# Altium schematic sheet ElectricalDiagram.SchDoc
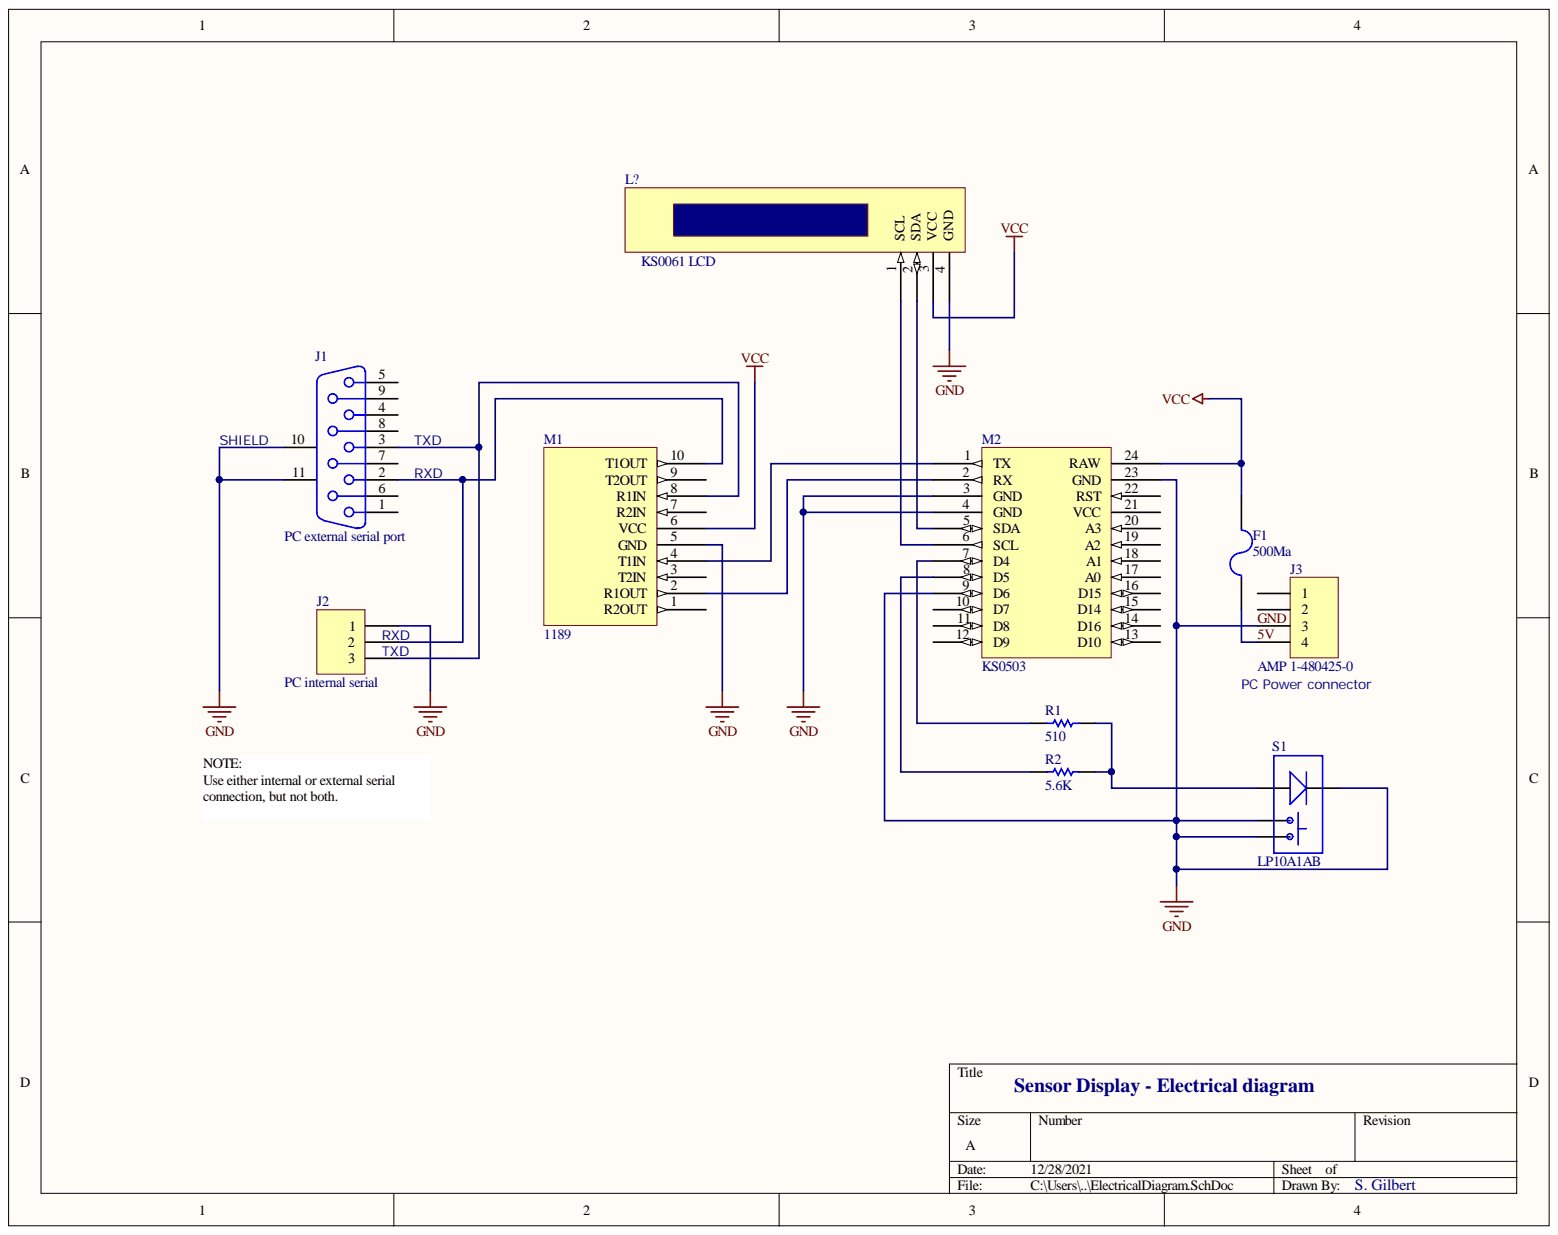
[diagram p0: ElectricalDiagram.SchDoc]

## Parts
F1  500Ma  [PIN-W2/E2.8]
J1  PC external serial port  [DSUB1.385-2H9]
J2  PC internal serial  [HDR1X3]
J3  AMP 1-480425-0  [HDR1X4]
L?  KS0061 LCD
M1  1189
M2  KS0503
R1  510  [AXIAL-0.3]
R2  5.6K  [AXIAL-0.3]
S1  LP10A1AB

## Nets
VCC: F1.2 L?.3(VCC) M1.6(VCC) M2.24(RAW)
5V: F1.1 J3.4
GND: J1.10 J1.11 J2.1 J3.3 L?.4(GND) M1.5(GND) M2.3(GND) M2.4(GND) M2.9(D6) M2.23(GND) S1.2 S1.3 S1.4
NetJ1_2: J1.2 J2.2 M1.10(T1OUT)
NetJ1_3: J1.3 J2.3 M1.8(R1IN)
NetL?_1: L?.1(SCL) M2.6(SCL)
NetL?_2: L?.2(SDA) M2.5(SDA)
NetM1_2: M1.2(R1OUT) M2.2(RX)
NetM1_4: M1.4(T1IN) M2.1(TX)
NetM2_7: M2.7(D4) R1.1
NetM2_8: M2.8(D5) R2.1
NetR1_2: R1.2 R2.2 S1.1

Not connected: J1 1 4 5 6 7 8 9; J3 1 2; M1 1(R2OUT) 3(T2IN) 7(R2IN) 9(T2OUT); M2 10(D7) 11(D8) 12(D9) 13(D10) 14(D16) 15(D14) 16(D15) 17(A0) 18(A1) 19(A2) 20(A3) 21(VCC) 22(RST)

## Library data of the parts
F1 = Fuse 2: Fuse; Component Kind: Standard; LatestRevisionDate: 17-Jul-2002; LatestRevisionNote: Re-released for DXP Platform.; Library Name: Miscellaneous Devices.IntLib; PackageDescription: Thru-Hole; 2 Leads; PackageReference: PIN-W2/E2.8; Published: 8-Jun-2000; Simulation: FUSE; Publisher: Altium Limited
J1 = D Connector 9: Receptacle Assembly, 9 Position, Right Angle; PackageDocument: O; Positions: 9; Component Kind: Standard; DatasheetDocument: 1-Sep-1999; Gender: Receptacle; LatestRevisionDate: 17-Jul-2002; LatestRevisionNote: Re-released for DXP Platform.; Library Name: Miscellaneous Connectors.IntLib; Orientation: Right Angle; PackageReference: 788750; Published: 15-Jun-2001; Publisher: Altium Limited
J2 = Header 3: Header, 3-Pin; Component Kind: Standard; LatestRevisionDate: 17-Jul-2002; LatestRevisionNote: Re-released for DXP Platform.; Library Name: Miscellaneous Connectors.IntLib; Publisher: Altium Limited
J3 = Header 4: Header, 4-Pin; Component Kind: Standard; LatestRevisionDate: 17-Jul-2002; LatestRevisionNote: Re-released for DXP Platform.; Library Name: Miscellaneous Connectors.IntLib; Publisher: Altium Limited
L? = KS0061: Component Kind: Standard; Library Name: SensorDisplay.SchLib
M1 = 11189: MAX3232 Tranceiver; Component Kind: Standard; Library Name: SensorDisplay.SchLib
R1 = Res1: Resistor; Component Kind: Standard; LatestRevisionDate: 17-Jul-2002; LatestRevisionNote: Re-released for DXP Platform.; Library Name: Miscellaneous Devices.IntLib; PackageDescription: Axial Device, Thru-Hole; 2 Leads; 0.3 in Pin Spacing; PackageReference: AXIAL-0.3; Published: 8-Jun-2000; Simulation: RESISTOR; Value: 510; Publisher: Altium Limited
R2 = Res1: Resistor; Value: 1K; Component Kind: Standard; LatestRevisionDate: 17-Jul-2002; LatestRevisionNote: Re-released for DXP Platform.; Library Name: Miscellaneous Devices.IntLib; PackageDescription: Axial Device, Thru-Hole; 2 Leads; 0.3 in Pin Spacing; PackageReference: AXIAL-0.3; Published: 8-Jun-2000; Simulation: RESISTOR; Publisher: Altium Limited
S1 = Push_Button_led: Component Kind: Standard; Library Name: SensorDisplay.SchLib
M2 = KS0503: Component Kind: Standard; Library Name: SensorDisplay.SchLib
